# Altium schematic sheet VCC33.SchDoc
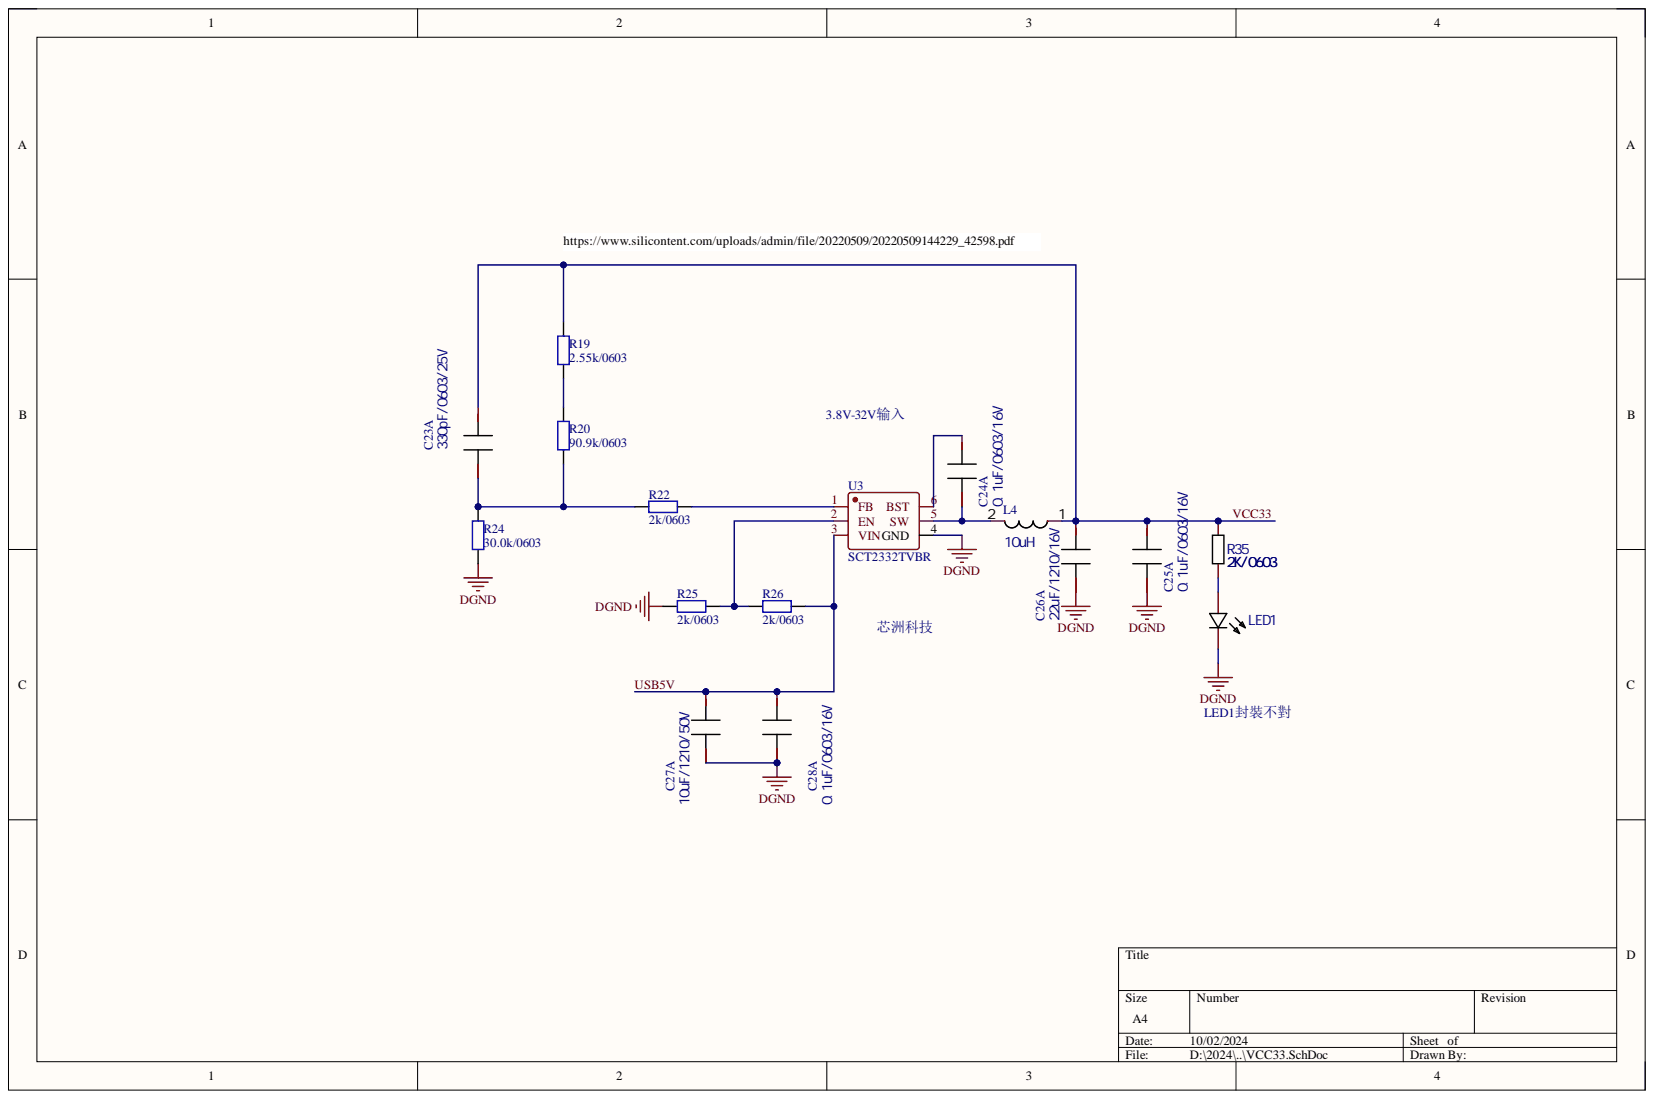
[diagram p0: VCC33.SchDoc]

## Parts
C23  330pF/0603/25V  [CAP0603]  (part A)
C24  0.1uF/0603/16V  [CAP0603]  (part A)
C25  0.1uF/0603/16V  [CAP0603]  (part A)
C26  22uF/1210/16V  [CAP1210-CAPC3225X140N]  (part A)
C27  10uF/1210/50V  [CAP1210-CAPC3225X140N]  (part A)
C28  0.1uF/0603/16V  [CAP0603]  (part A)
L4  10uH  [IND-SMD_L4.7-W4.7-6.5uH]
LED1  19-217/GHC-YR1S2/3T  [LED_0603_KA]  (value not drawn)
R19  2.55k/0603  [RES0603]
R20  90.9k/0603  [RES0603]
R22  2k/0603  [RES0603]
R24  30.0k/0603  [RES0603]
R25  2k/0603  [RES0603]
R26  2k/0603  [RES0603]
R35  2K/0603  [RES0603]
U3  SCT2332TVBR  [SCT2332TVBR-TSOT23-6]

## Nets
DGND: C25.2 C26.2 C27.2 C28.2 LED1.2 R24.1 R25.2 U3.4(GND)
USB5V: C27.1 C28.1 R26.1 U3.3(VIN)
VCC33: C23.1 C25.1 C26.1 L4.1 R19.2 R35.1
NetC23_2: C23.2 R20.1 R22.2 R24.2
NetC24_1: C24.1 U3.6(BST)
NetC24_2: C24.2 L4.2 U3.5(SW)
NetLED1_1: LED1.1 R35.2
NetR19_1: R19.1 R20.2
NetR22_1: R22.1 U3.1(FB)
NetR25_1: R25.1 R26.2 U3.2(EN)

## Library data of the parts
C23 = CAP0603: SURFACE MOUNT CAPACITOR 0.031 X 0.061 INCHES; Manufacturer #1: IPC SM-782 STD.; Add into BOM: yes; Origin Footprint: 0603; Sim_Analog_Order: Model$; Component Kind: Standard; Convert to PCB: yes; Device: CAP0603; Name: CAP0603; OldName: CAP0603; PCB DECAL: 0603; Symbol: CAP0603; Value: 330pF/0603/25V
C24 C25 C28 = CAP0603: SURFACE MOUNT CAPACITOR 0.031 X 0.061 INCHES; Manufacturer #1: IPC SM-782 STD.; Add into BOM: yes; Origin Footprint: 0603; Sim_Analog_Order: Model$; Value: 0.1uF/0603/50V; Component Kind: Standard; Convert to PCB: yes; Device: CAP0603; Name: CAP0603; OldName: CAP0603; PCB DECAL: 0603; Symbol: CAP0603
C26 = CAP0603: SURFACE MOUNT CAPACITOR 0.031 X 0.061 INCHES; Manufacturer #1: IPC SM-782 STD.; Add into BOM: yes; Origin Footprint: 0603; Sim_Analog_Order: Model$; Value: 22uF/1210/35V; Component Kind: Standard; Convert to PCB: yes; Device: CAP0603; Name: CAP0603; OldName: CAP0603; PCB DECAL: 0603; Symbol: CAP0603
C27 = CAP0603: SURFACE MOUNT CAPACITOR 0.031 X 0.061 INCHES; Manufacturer #1: IPC SM-782 STD.; Add into BOM: yes; Origin Footprint: 0603; Sim_Analog_Order: Model$; Value: 10uF/1210/35V; Component Kind: Standard; Convert to PCB: yes; Device: CAP0603; Name: CAP0603; OldName: CAP0603; PCB DECAL: 0603; Symbol: CAP0603
L4 = IND-MOLDED: Add into BOM: yes; Description" MOLDED INDUCTOR, 0_5: PIN SPACING; Origin Footprint: L_0650; Sim_Analog_Order: Model$; Sim_Analog_Prefix: L; Component Kind: Standard; Convert to PCB: yes; Device: IND-MOLDED; Name: IND-MOLDED; OldName: IND-MOLDED; PCB DECAL: L_0650; Symbol: IND-MOLDED; Value: 10uH
LED1 = 19-217/GHC-YR1S2/3T: Manufacturer: EVERLIGHT; Add into BOM: yes; Origin Footprint: LED0603-R-RD; Component Kind: Standard; Convert to PCB: yes; Device: 19-217/GHC-YR1S2/3T; JLCPCB Part Class: Basic Part; Manufacturer Part: 19-217/GHC-YR1S2/3T; Name: 19-217/GHC-YR1S2/3T; Supplier: LCSC; Supplier Part: C72043; Symbol: 19-217/GHC-YR1S2/3T
R19 R20 R22 R24-R26 = Res2: Resistor; Component Kind: Standard; LatestRevisionDate: 17-Jul-2002; LatestRevisionNote: Re-released for DXP Platform.; Library Name: Miscellaneous Devices.IntLib; PackageDescription: Axial Device, Thru-Hole; 2 Leads; 0.4 in Pin Spacing; PackageReference: AXIAL-0.4; Published: 8-Jun-2000; Signal Integrity: Res; Simulation: RESISTOR; Publisher: Altium Limited
R35 = 2.2K: Manufacturer: UniOhm; Manufacturer Part: 0402WGF2201TCE; Add into BOM: yes; Origin Footprint: R0402; Component Kind: Standard; Convert to PCB: yes; Device: 2.2K; JLCPCB Part Class: Basic Part; Name: 2K/0603; Supplier: LCSC; Supplier Part: C25879; Symbol: 2.2K
U3 = SCT2332TVBR: 3.8V-32V Vin, 3A Synchronous Step-down DCDC Converter; Component Kind: Standard; Library Name: ChargingPile.SchLib
